# Altium schematic sheet Sheet1.SchDoc
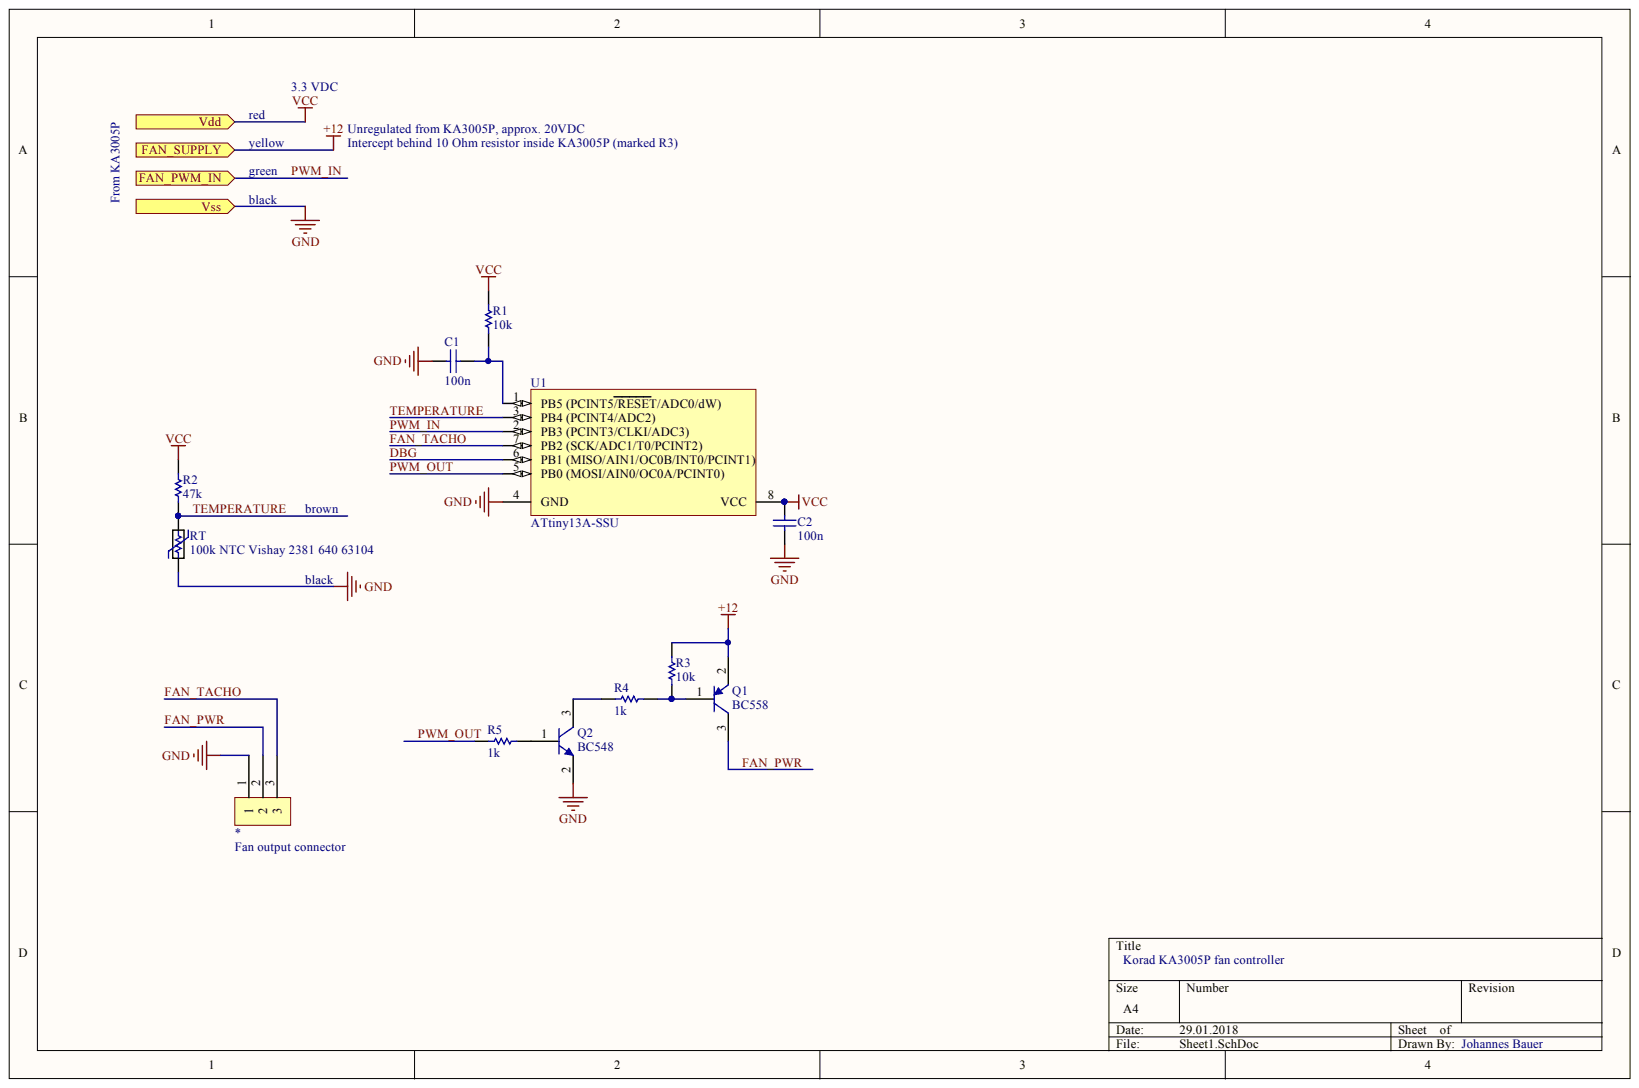
[diagram p0: Sheet1.SchDoc]

## Parts
*  Fan output connector
C1  100n  [CAPC2013X100X50NL20T25]
C2  100n  [CAPC2013X100X50NL20T25]
Q1  BC558  [ONSC-SOT-23-3-318-08_V]
Q2  BC548  [ONSC-SOT-23-3-318-08_V]
R1  10k
R2  47k
R3  10k
R4  1k
R5  1k
RT  100k NTC Vishay 2381 640 63104  [RESC2012X09L]
U1  ATtiny13A-SSU  [8S1_L]

## Ports
FAN_PWM_IN, FAN_SUPPLY, VDD, VSS

## Nets
GND: *.1 C1.1 C2.2 Q2.2 RT.2 U1.4(GND) ; port VSS
VCC: C2.1 R1.1 R2.2 U1.8(VCC) ; port VDD
+12: Q1.2 R3.1 ; port FAN_SUPPLY
DBG: U1.6(PB1_(MISO/AIN1/OC0B/INT0/PCINT1))
FAN_PWR: *.2 Q1.3
FAN_TACHO: *.3 U1.7(PB2_(SCK/ADC1/T0/PCINT2))
PWM_IN: U1.2(PB3_(PCINT3/CLKI/ADC3)) ; port FAN_PWM_IN
PWM_OUT: R5.2 U1.5(PB0_(MOSI/AIN0/OC0A/PCINT0))
TEMPERATURE: R2.1 RT.1 U1.3(PB4_(PCINT4/ADC2))
NetC1_2: C1.2 R1.2 U1.1(PB5_(PCINT5/RESET/ADC0/dW))
NetQ1_1: Q1.1 R3.2 R4.1
NetQ2_1: Q2.1 R5.1
NetQ2_3: Q2.3 R4.2

## Library data of the parts
* = 22-05-3031: Header Connector, PCB Mount, RECEPT, 3 Contacts, PIN, 0.1 Pitch, PC TAIL Terminal, POLARIZED LCK; Current Rating: 4.00 A; Gender: Male; Glow Wire Compliant: Non-Compliant; Insulation Resistance: 50.0 GΩ; Lead-Free Status: Lead Free; Number of Circuits: 3; Pin Pitch: 2.54 mm; Voltage Rating (DC): 250 V; Color: White; Component Kind: Standard; Contact Material: Brass; Contact Plating: Tin; Flammability Rating: UL 94 V-0; Halogen Free Status: Low Halogen; Housing Color: White; Housing Material: Nylon; Library Name: Octopart library; Lifecycle Status: Active; Manufacturer Part Number: 22-05-3031; Mounting Style: Through Hole, Solder; Number of Contacts: 3; Number of Positions: 3; Number of Rows: 1; OctopartID: ac1a651098b073dd; Octopart URL: http://octopart.com/22-05-3031-molex-4556; Orientation: Right Angle; Packaging: Bulk; RoHS: Compliant; Size-Height: 6.35 mm; Size-Length: 7.62 mm; Size-Width: 8.18 mm
C1 C2 = C0805F222K1RACAUTO: KEMET   C0805F222K1RACAUTO   CAP, MLCC, X7R, 2.2NF, 100V, 0805; Capacitance: 2.2e-09 F; Capacitance Tolerance: ±10%; Dielectric Material: Ceramic; Lead-Free Status: R; Voltage Rating (DC): 100.0 V; Case/Package: 0805; Component Kind: Standard; Dielectric Characteristic: X7R; Library Name: Octopart library; Mounting Style: Surface Mount; Number of Pins: 2; OctopartID: 725dba794652ad9a; Octopart Url: https://octopart.com/c0805f222k1racauto-kemet-19796329; Packaging: Cut Tape (CT); REACH SVHC Compliance: No SVHC; RoHS: Compliant
Q1 = SMMBT4403LT1G: Switching Transistor, PNP Silicon, 3-Pin SOT-23, Pb-Free, Tape and Reel; Lead-Free Status: Lead Free; Component Kind: Standard; Library Name: Octopart library; Lifecycle Status: Active; OctopartID: 6405ac5efc6abbc2; Octopart Url: https://octopart.com/smmbt4403lt1g-on+semiconductor-22134025; RoHS: Compliant
Q2 = BC846BLT3G: General Purpose Transistor, NPN Silicon, 3-Pin SOT-23, Pb-Free, Tape and Reel; Current Rating: 0.1 A; Lead-Free Status: Lead Free; Voltage Rating (DC): 65.0 V; Case/Package: SOT-23; Component Kind: Standard; Halogen Free Status: Halogen Free; Library Name: Octopart library; Lifecycle Status: Active; Mounting Style: Surface Mount; OctopartID: 364eff51e681e989; Octopart Url: https://octopart.com/bc846blt3g-on+semiconductor-1439145; Packaging: Tape; Polarity: NPN; RoHS: Compliant
R1-R5 = RP73PF2A8K45BTDF: Res, Thin Film, 8k45, 0.1%, 0.25w, 0805; Power Rating: 250 mW; Resistance: 8.45 kΩ; Voltage Rating (DC): 150 V; Case/Package: 0805, 2012; Component Kind: Standard; Library Name: Octopart library; Lifecycle Status: Not Listed by Manufacturer; Manufacturer Part Number: RP73PF2A8K45BTDF; Mounting Style: Surface Mount; OctopartID: 6daca6138ed3b26b; Octopart URL: http://octopart.com/rp73pf2a8k45btdf-te+connectivity-45467161; Packaging: Cut Tape (CT); REACH SVHC Compliance: No SVHC; Resistance Tolerance: ±0.1%; RoHS: Compliant
RT = NCP21XV103J03RA: Thermistor NTC 10K Ohm 5% 2-Pin 0805 Surface Mount Solder Pad 3934K T/R; Case/Package (SI): 2012; Lead-Free Status: Lead Free; Resistance: 10.0 kΩ; Component Kind: Standard; Library Name: Octopart library; Octopart URL: http://octopart.com/ncp21xv103j03ra-murata-745720; Size-Thickness: 150 µm
U1 = ATTINY13A-SSU: 8-bit AVR Microcontroller, 1.8-5.5V, 20MHz, 1KB Flash, 64 Bytes EEPROM, 64 Bytes SRAM, 8-pin SOIC, Industrial Grade (-40°C to 85°C), Pb-Free; Manufacturer: Atmel; ADC Channels: 4; ADC Resolution: 10bit; ADCs: 4 x 10 bit; CiivaID: 973671; Data Bus Width: 8Bit; Family Name: ATtiny; Instruction Set Architecture: RISC; Maximum Number of CAN Channels: 0; Maximum Number of SPI Channels: 1; Program Memory Size: 1 kB, 64 B; Pulse Width Modulation: 2 x 8 bit; PWM Channels: 2; RAM Size: 64 B; Timers: 1 x 8 bit; Typical Operating Supply Voltage: 1.8  5.5 V; Ciiva URL: https://ciiva.com/part/attiny13a-ssu-973671; Component Kind: Standard; Device Core: AVR; Dimensions: 5 x 4 x 1.5mm; Height: 1.5mm; Length: 5mm; Library Name: Octopart library; Maximum Frequency: 20MHz; Maximum Number of Ethernet Channels: 0; Maximum Number of I2C Channels: 0; Maximum Number of LIN Channels: 0; Maximum Number of PCI Channels: 0; Maximum Number of UART Channels: 0; Maximum Number of USART Channels: 0; Maximum Operating Temperature: +85 C; Minimum Operating Temperature: -40 C; Mounting Type: Surface Mount; Number of ADC Units: 1; Number of PWM Units: 1; Number of Timers: 1; Package Type: SOIC; Pin Count: 8; Program Memory Type: Flash; PWM Resolution: 8bit; Timer Resolution: 8bit; USB Channels: 0; Width: 4mm
